# Altium schematic sheet 工程车主控V2.0-2.SchDoc(工程车主控V2.0-2)
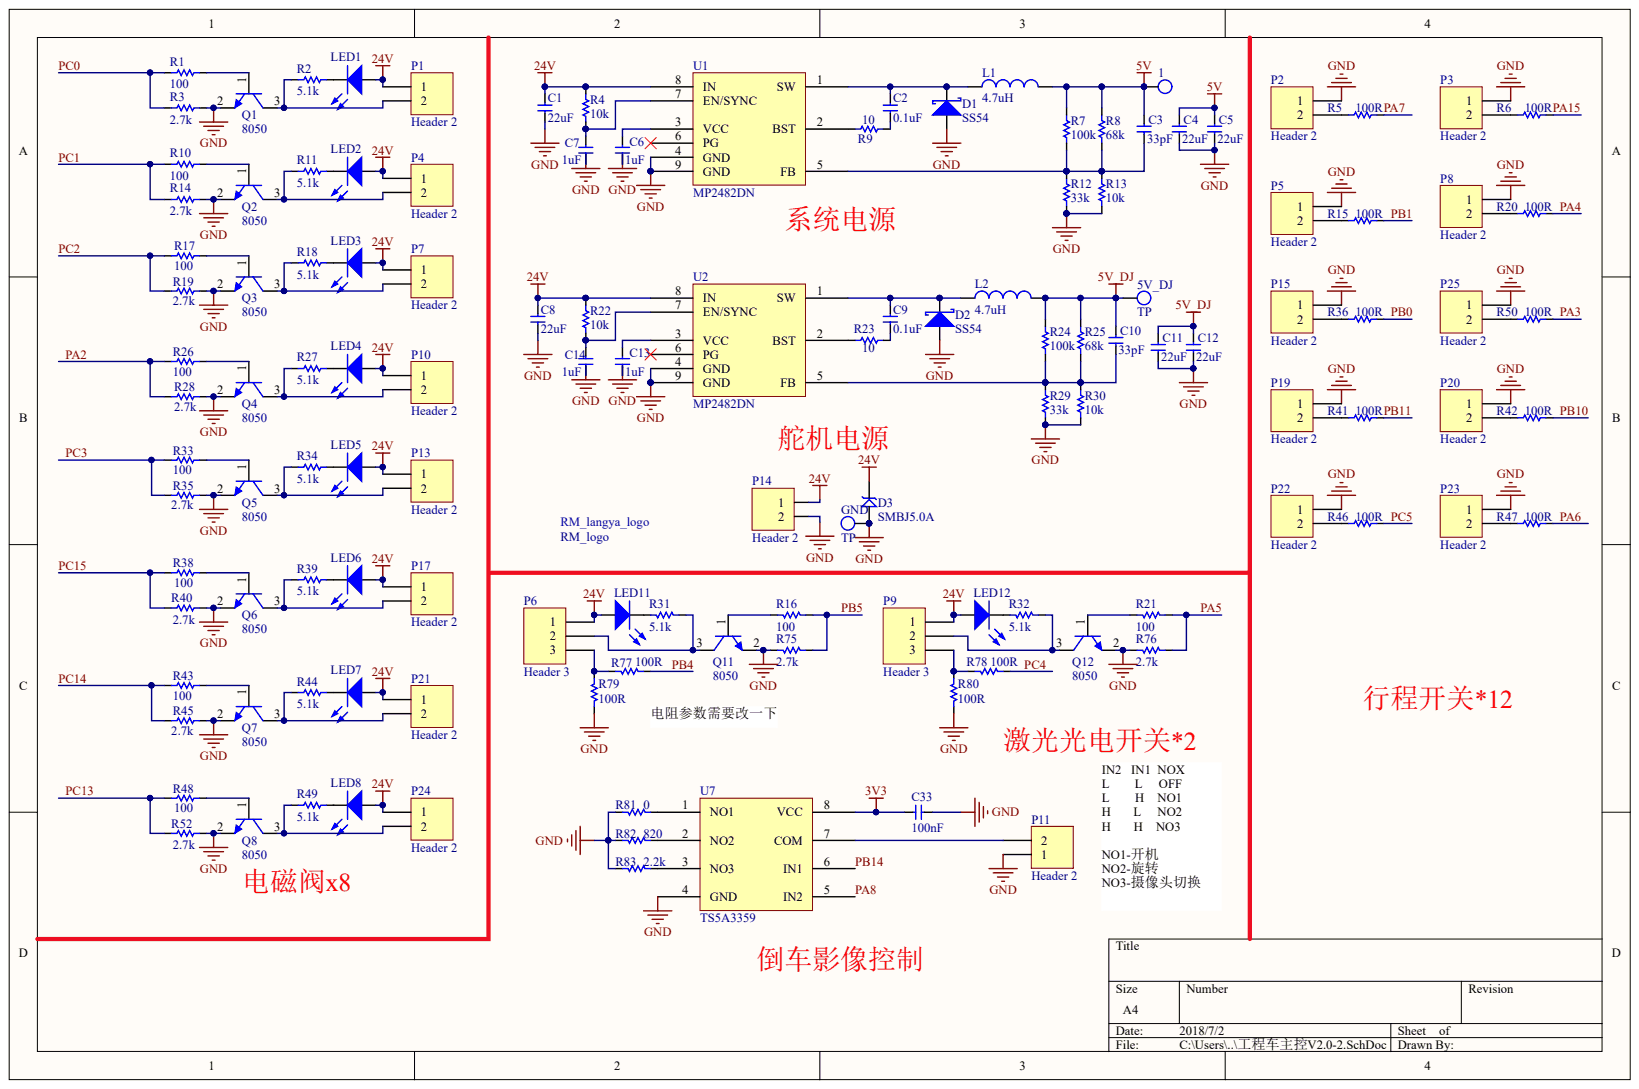
[diagram p0: 工程车主控V2.0-2.SchDoc]

## Parts
1  TP  [TP_SMT]  (value not drawn)
5V_DJ  TP  [TP_SMT]
C1  22uF  [1206C]
C2  0.1uF  [CAPC1608N]
C3  33pF  [0603C]
C4  22uF  [0805]
C5  22uF  [0805]
C6  1uF  [CAPC1608N]
C7  1uF  [CAPC1608N]
C8  22uF  [1206C]
C9  0.1uF  [CAPC1608N]
C10  33pF  [0603C]
C11  22uF  [0805]
C12  22uF  [0805]
C13  1uF  [CAPC1608N]
C14  1uF  [CAPC1608N]
C33  100nF  [0603C]
D1  SS54  [SMA/DO-214AC]
D2  SS54  [SMA/DO-214AC]
D3  SMBJ5.0A  [SMB/DO-214AA]
GND  TP  [TP_VIA]
L1  value 4.7uH  [0420L]  (hidden comment: Inductor)
L2  value 4.7uH  [0630L]  (hidden comment: Inductor)
LED1  LED_RED  [0603LED_B]  (value not drawn)
LED2  LED_RED  [0603LED_B]  (value not drawn)
LED3  LED_RED  [0603LED_B]  (value not drawn)
LED4  LED_RED  [0603LED_B]  (value not drawn)
LED5  LED_RED  [0603LED_B]  (value not drawn)
LED6  LED_RED  [0603LED_B]  (value not drawn)
LED7  LED_RED  [0603LED_B]  (value not drawn)
LED8  LED_RED  [0603LED_B]  (value not drawn)
LED11  LED_RED  [0603LED_B]  (value not drawn)
LED12  LED_RED  [0603LED_B]  (value not drawn)
P1  Header 2  [HY2.00MM 2X1_立式]
P2  Header 2  [HY2.00MM 2X1_立式]
P3  Header 2  [HY2.00MM 2X1_立式]
P4  Header 2  [HY2.00MM 2X1_立式]
P5  Header 2  [HY2.00MM 2X1_立式]
P6  Header 3  [HY2.00MM 3x1立式]
P7  Header 2  [HY2.00MM 2X1_立式]
P8  Header 2  [HY2.00MM 2X1_立式]
P9  Header 3  [HY2.00MM 3x1立式]
P10  Header 2  [HY2.00MM 2X1_立式]
P11  Header 2  [HY2.00MM 2X1_立式]
P13  Header 2  [HY2.00MM 2X1_立式]
P14  Header 2  [XT30PW-Male]
P15  Header 2  [HY2.00MM 2X1_立式]
P17  Header 2  [HY2.00MM 2X1_立式]
P19  Header 2  [HY2.00MM 2X1_立式]
P20  Header 2  [HY2.00MM 2X1_立式]
P21  Header 2  [HY2.00MM 2X1_立式]
P22  Header 2  [HY2.00MM 2X1_立式]
P23  Header 2  [HY2.00MM 2X1_立式]
P24  Header 2  [HY2.00MM 2X1_立式]
P25  Header 2  [HY2.00MM 2X1_立式]
Q1  8050  [SOT-23-3]
Q2  8050  [SOT-23-3]
Q3  8050  [SOT-23-3]
Q4  8050  [SOT-23-3]
Q5  8050  [SOT-23-3]
Q6  8050  [SOT-23-3]
Q7  8050  [SOT-23-3]
Q8  8050  [SOT-23-3]
Q11  8050  [SOT-23-3]
Q12  8050  [SOT-23-3]
R1  100  [0603R]
R2  5.1k  [0603R]
R3  2.7k  [0603R]
R4  10k  [RESC1608N]
R5  100R  [0603R]
R6  100R  [0603R]
R7  100k  [RESC1608N]
R8  68k  [RESC1608N]
R9  10  [RESC1608N]
R10  100  [0603R]
R11  5.1k  [0603R]
R12  33k  [RESC1608N]
R13  10k  [RESC1608N]
R14  2.7k  [0603R]
R15  100R  [0603R]
R16  100  [0603R]
R17  100  [0603R]
R18  5.1k  [0603R]
R19  2.7k  [0603R]
R20  100R  [0603R]
R21  100  [0603R]
R22  10k  [RESC1608N]
R23  10  [RESC1608N]
R24  100k  [RESC1608N]
R25  68k  [RESC1608N]
R26  100  [0603R]
R27  5.1k  [0603R]
R28  2.7k  [0603R]
R29  33k  [RESC1608N]
R30  10k  [RESC1608N]
R31  5.1k  [0603R]
R32  5.1k  [0603R]
R33  100  [0603R]
R34  5.1k  [0603R]
R35  2.7k  [0603R]
R36  100R  [0603R]
R38  100  [0603R]
R39  5.1k  [0603R]
R40  2.7k  [0603R]
R41  100R  [0603R]
R42  100R  [0603R]
R43  100  [0603R]
R44  5.1k  [0603R]
R45  2.7k  [0603R]
R46  100R  [0603R]
R47  100R  [0603R]
R48  100  [0603R]
R49  5.1k  [0603R]
R50  100R  [0603R]
R52  2.7k  [0603R]
R75  2.7k  [0603R]
R76  2.7k  [0603R]
R77  100R  [0603R]
R78  100R  [0603R]
R79  100R  [0603R]
R80  100R  [0603R]
R81  0  [0603R]
R82  820  [0603R]
R83  2.2k  [0603R]
U1  MP2482DN  [SOIC8E]
U2  MP2482DN  [SOIC8E]
U7  TS5A3359  [VSSOP(DCU)]
  RM_logo  [Logo_LangYa]

## Nets
3V3: C33.1 U7.8(VCC)
5V: 1.1 C3.2 C4.2 C5.2 L1.2 R7.2 R8.2
5V_DJ: 5V_DJ.1 C10.2 C11.2 C12.2 L2.2 R24.2 R25.2
24V: C1.2 C8.2 D3.2 LED1.1 LED2.1 LED3.1 LED4.1 LED5.1 LED6.1 LED7.1 LED8.1 LED11.1 LED12.1 P1.1 P4.1 P6.1 P7.1 P9.1 P10.1 P13.1 P14.1 P17.1 P21.1 P24.1 R4.2 R22.2 U1.8(IN) U2.8(IN)
GND: C1.1 C4.1 C5.1 C6.2 C7.2 C8.1 C11.1 C12.1 C13.2 C14.2 C33.2 D1.1 D2.1 D3.1 GND.1 P2.1 P3.1 P5.1 P8.1 P11.1 P14.2 P15.1 P19.1 P20.1 P22.1 P23.1 P25.1 Q1.2 Q2.2 Q3.2 Q4.2 Q5.2 Q6.2 Q7.2 Q8.2 Q11.2 Q12.2 R3.2 R12.1 R13.1 R14.2 R19.2 R28.2 R29.1 R30.1 R35.2 R40.2 R45.2 R52.2 R75.2 R76.2 R79.2 R80.2 R81.2 R82.2 R83.2 U1.4(GND) U1.9(GND) U2.4(GND) U2.9(GND) U7.4(GND)
PA2: R26.1 R28.1
PA3: R50.1
PA4: R20.1
PA5: R21.1 R76.1
PA6: R47.1
PA7: R5.1
PA8: U7.5(IN2)
PA15: R6.1
PB0: R36.1
PB1: R15.1
PB4: R77.2
PB5: R16.1 R75.1
PB10: R42.1
PB11: R41.1
PB14: U7.6(IN1)
PC0: R1.1 R3.1
PC1: R10.1 R14.1
PC2: R17.1 R19.1
PC3: R33.1 R35.1
PC4: R78.2
PC5: R46.1
PC13: R48.1 R52.1
PC14: R43.1 R45.1
PC15: R38.1 R40.1
NetC2_1: C2.1 R9.1
NetC2_2: C2.2 D1.2 L1.1 U1.1(SW)
NetC3_1: C3.1 R7.1 R8.1 R12.2 R13.2 U1.5(FB)
NetC6_1: C6.1 U1.3(VCC)
NetC7_1: C7.1 R4.1 U1.7(EN/SYNC)
NetC9_1: C9.1 R23.1
NetC9_2: C9.2 D2.2 L2.1 U2.1(SW)
NetC10_1: C10.1 R24.1 R25.1 R29.2 R30.2 U2.5(FB)
NetC13_1: C13.1 U2.3(VCC)
NetC14_1: C14.1 R22.1 U2.7(EN/SYNC)
NetLED1_2: LED1.2 R2.2
NetLED2_2: LED2.2 R11.2
NetLED3_2: LED3.2 R18.2
NetLED4_2: LED4.2 R27.2
NetLED5_2: LED5.2 R34.2
NetLED6_2: LED6.2 R39.2
NetLED7_2: LED7.2 R44.2
NetLED8_2: LED8.2 R49.2
NetLED11_2: LED11.2 R31.2
NetLED12_2: LED12.2 R32.2
NetP1_2: P1.2 Q1.3 R2.1
NetP2_2: P2.2 R5.2
NetP3_2: P3.2 R6.2
NetP4_2: P4.2 Q2.3 R11.1
NetP5_2: P5.2 R15.2
NetP6_2: P6.2 Q11.3 R31.1
NetP6_3: P6.3 R77.1 R79.1
NetP7_2: P7.2 Q3.3 R18.1
NetP8_2: P8.2 R20.2
NetP9_2: P9.2 Q12.3 R32.1
NetP9_3: P9.3 R78.1 R80.1
NetP10_2: P10.2 Q4.3 R27.1
NetP11_2: P11.2 U7.7(COM)
NetP13_2: P13.2 Q5.3 R34.1
NetP15_2: P15.2 R36.2
NetP17_2: P17.2 Q6.3 R39.1
NetP19_2: P19.2 R41.2
NetP20_2: P20.2 R42.2
NetP21_2: P21.2 Q7.3 R44.1
NetP22_2: P22.2 R46.2
NetP23_2: P23.2 R47.2
NetP24_2: P24.2 Q8.3 R49.1
NetP25_2: P25.2 R50.2
NetQ1_1: Q1.1 R1.2
NetQ2_1: Q2.1 R10.2
NetQ3_1: Q3.1 R17.2
NetQ4_1: Q4.1 R26.2
NetQ5_1: Q5.1 R33.2
NetQ6_1: Q6.1 R38.2
NetQ7_1: Q7.1 R43.2
NetQ8_1: Q8.1 R48.2
NetQ11_1: Q11.1 R16.2
NetQ12_1: Q12.1 R21.2
NetR9_2: R9.2 U1.2(BST)
NetR23_2: R23.2 U2.2(BST)
NetR81_1: R81.1 U7.1(NO1)
NetR82_1: R82.1 U7.2(NO2)
NetR83_1: R83.1 U7.3(NO3)

Not connected: U1 6(PG); U2 6(PG)

## Library data of the parts
1 5V_DJ GND = TP: Test Point; Component Kind: Standard; Library Name: MyComponents.SchLib
C1-C14 C33 = Cap: Component Kind: Standard; Library Name: WolferWu.SchLib
  C1 C4 C5 C8 C11 C12: Value: 22uF
  C2 C9: Value: 0.1uF
  C3 C10: Value: 33pF
  C6 C7 C13 C14: Value: 1uF
  C33: Value: 100nF
D1 D2 = D Schottky: Schottky Diode; Code_JEDEC: DO-214-AA; Component Kind: Standard; LatestRevisionDate: 17-Jul-2002; LatestRevisionNote: Re-released for DXP Platform.; Library Name: MyComponents.SchLib; PackageDescription: DO-214-AA/SMB; 2 C-Bend Leads; Body 5.3 x 3.6 mm, inc. leads (LxW); PackageReference: SMB; Published: 8-Jun-2000; Signal Integrity: D Schottky; Simulation: SKYDIODE; Publisher: Altium Limited
D3 = SMBJ: TVS; Component Kind: Standard; Library Name: MyComponents.SchLib
L1 L2 = Inductor: Code_IEC: 1005; Code_JEITA: 0402; Component Kind: Standard; Description: Inductor; LatestRevisionDate: 29-May-2009; LatestRevisionNote: IPC-7351 Footprint Added.; Library Name: Miscellaneous Devices.IntLib; PackageDescription: Chip Inductor; PackageReference: 0402-A; Published: 8-Jun-2000; Signal Integrity: Inductor; Simulation: INDUCTOR; Publisher: Altium Limited
LED1-LED8 LED11 LED12 = LED: Typical RED, GREEN, YELLOW, AMBER GaAs LED; Component Kind: Standard; LatestRevisionDate: 17-Jul-2002; LatestRevisionNote: Re-released for DXP Platform.; Library Name: MyComponents.IntLib; PackageDescription: SM LED; 2 Flat Leads; PackageReference: 3.2X1.6X1.1; PackageVersion: Feb-2002; Published: 8-Jun-2000; Simulation: LED2; Publisher: Altium Limited
P1-P5 P7 P8 P10 P11 P13-P15 P17 P19-P25 = Header 2: Header, 2-Pin; Component Kind: Standard; LatestRevisionDate: 17-Jul-2002; LatestRevisionNote: Re-released for DXP Platform.; Library Name: Miscellaneous Connectors.IntLib; Signal Integrity: Connector; Publisher: Altium Limited
P6 P9 = Header 3: Header, 3-Pin; Component Kind: Standard; LatestRevisionDate: 17-Jul-2002; LatestRevisionNote: Re-released for DXP Platform.; Library Name: Miscellaneous Connectors.IntLib; Signal Integrity: Connector; Publisher: Altium Limited
Q1-Q8 Q11 Q12 = 8050(NPN)SMT: NPN 贴片; Code_JEDEC: TO-226-AA; Component Kind: Standard; LatestRevisionDate: 17-Jul-2002; LatestRevisionNote: Re-released for DXP Platform.; Library Name: MyComponents.IntLib; PackageDescription: TO, Flat Index; 3 In-Line, Axial Leads; Body Dia. 4.8mm; Leads 0.55 x 0.51 mm (max); PackageReference: TO-226-AA; Published: 8-Jun-2000; Signal Integrity: NPN; Simulation: NPN; Publisher: Altium Limited
R1-R3 R5 R6 R10 R11 R14-R21 R26-R28 R31-R36 R38-R50 R52 R75-R80 = Res1: Resistor; Value: 1K; Component Kind: Standard; LatestRevisionDate: 17-Jul-2002; LatestRevisionNote: Re-released for DXP Platform.; PackageDescription: Axial Device, Thru-Hole; 2 Leads; 0.3 in Pin Spacing; PackageReference: AXIAL-0.3; Published: 8-Jun-2000; Signal Integrity: Res; Simulation: RESISTOR; Publisher: Altium Limited
  R1-R3 R10 R11 R14 R16-R19 R21 R26-R28 R31-R35 R38-R40 R43-R45 R48 R49 R52 R75 R76: Library Name: MyComponents.IntLib
  R5 R6 R15 R20 R36 R41 R42 R46 R47 R50 R77-R80: Library Name: MyComponents.SchLib
R4 R7-R9 R12 R13 R22-R25 R29 R30 = Res: Component Kind: Standard; Description: Res; Library Name: WolferWu.SchLib
  R4 R13 R22 R30: Vaule: 10k
  R7 R24: Vaule: 100k
  R8 R25: Vaule: 68k
  R9 R23: Vaule: 10
  R12 R29: Vaule: 33k
R81-R83 = Res1: Resistor; Component Kind: Standard; LatestRevisionDate: 17-Jul-2002; LatestRevisionNote: Re-released for DXP Platform.; Library Name: MyComponents.IntLib; PackageDescription: Axial Device, Thru-Hole; 2 Leads; 0.3 in Pin Spacing; PackageReference: AXIAL-0.3; Published: 8-Jun-2000; Signal Integrity: Res; Simulation: RESISTOR; Publisher: Altium Limited
  R81: Value: 0
  R82: Value: 820
  R83: Value: 2.2k
U1 U2 = MP2482DN: 开关电源5A; Component Kind: Standard; Library Name: MyComponents.SchLib
U7 = TS5A3359: 模拟开关（单刀三掷）; Component Kind: Standard; Library Name: MyComponents_v2.4.SchLib
 = RM_logo: Component Kind: Standard; Library Name: MyComponents_v2.3.SchLib
